# Altium schematic sheet AUDIO&TF.SchDoc(AUDIO&TF)
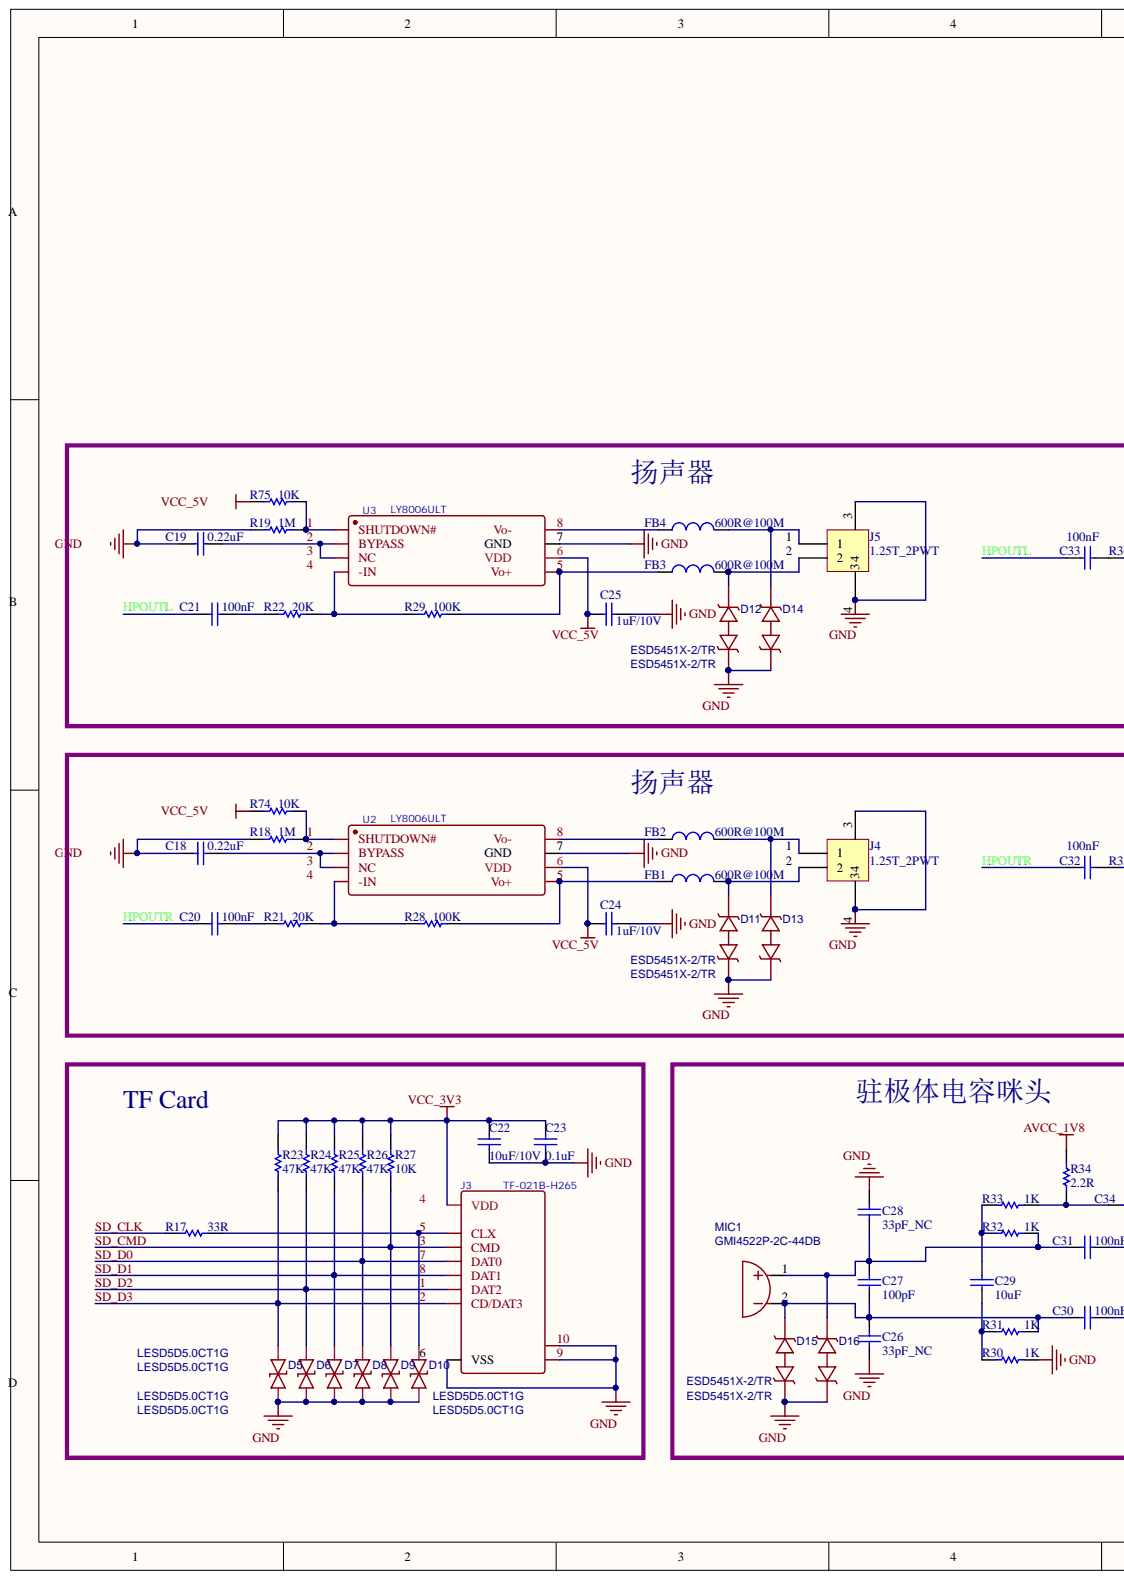
[diagram p0: AUDIO&TF.SchDoc, left]
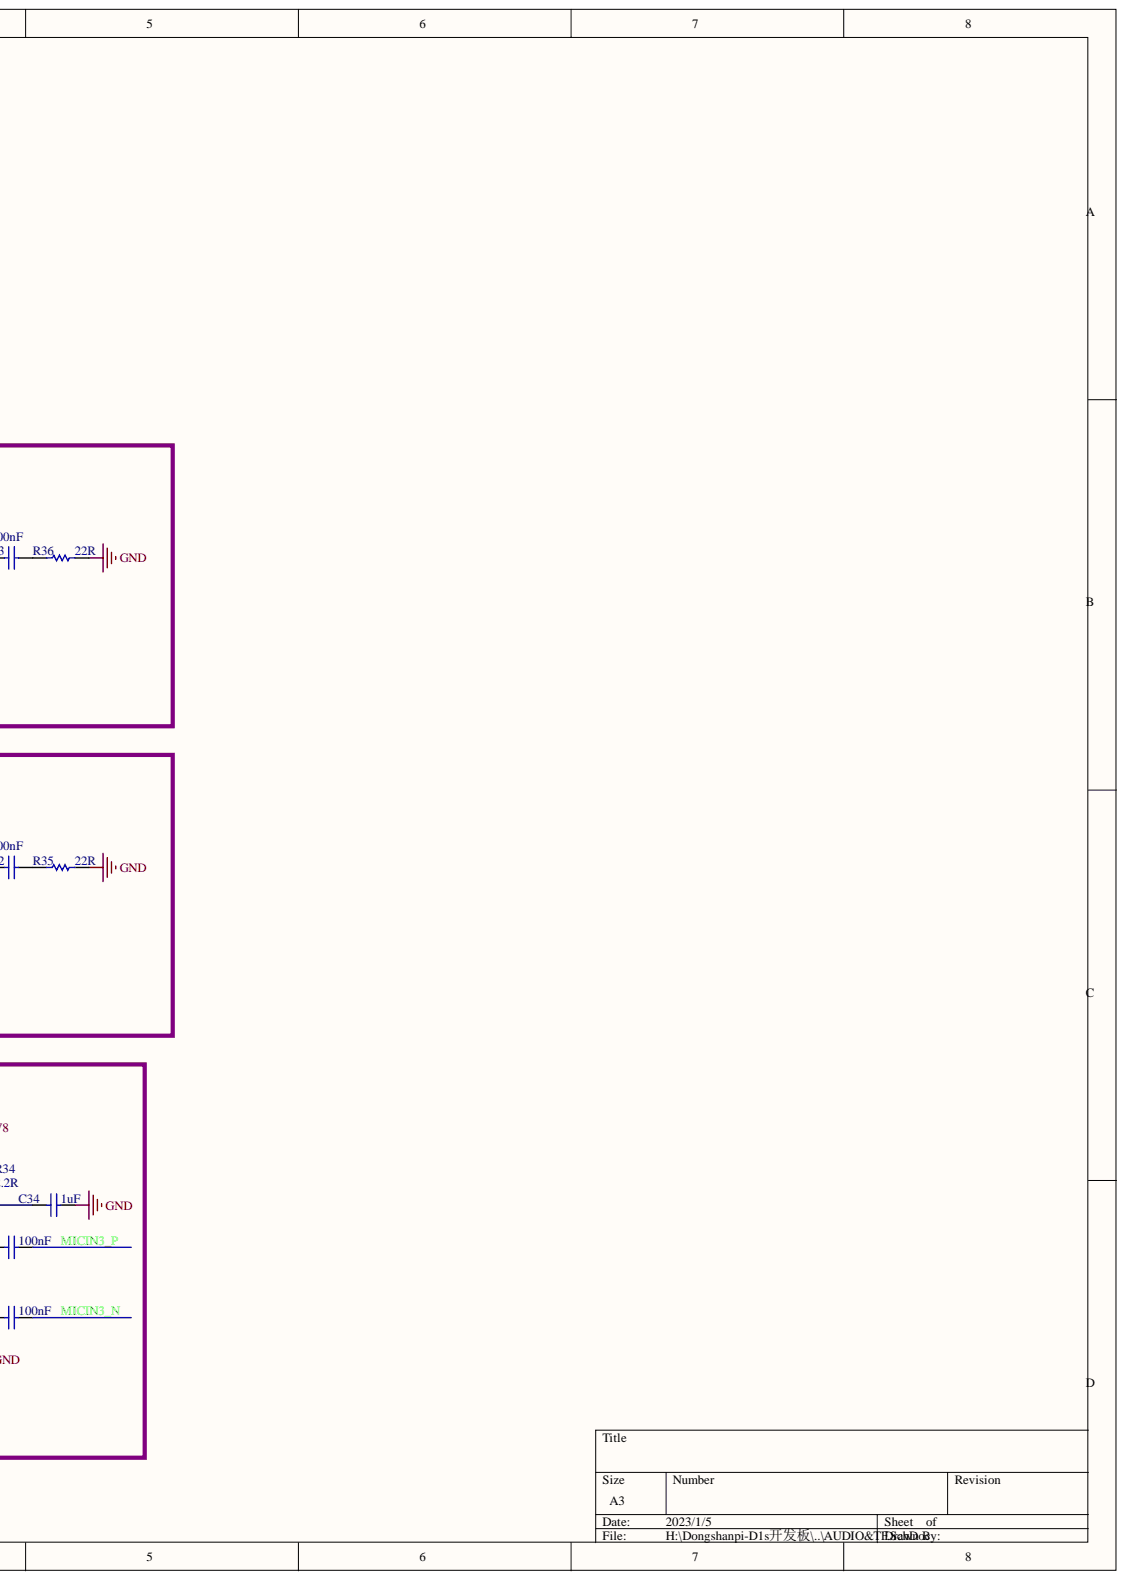
[diagram p1: AUDIO&TF.SchDoc, right]

## Parts
C18  0.22uF  [0402]
C19  0.22uF  [0402]
C20  100nF  [0402]
C21  100nF  [0402]
C22  10uF/10V  [0402]
C23  0.1uF  [0402]  (value not drawn)
C24  1uF/10V  [0402]
C25  1uF/10V  [0402]
C26  33pF_NC  [0402]
C27  100pF  [0402]
C28  33pF_NC  [0402]
C29  10uF  [0603]
C30  100nF  [0402]
C31  100nF  [0402]
C32  100nF  [0402]
C33  100nF  [0402]
C34  1uF  [0402]
D5  LESD5D5.0CT1G  [SOD-523_L1.2-W0.8-LS1.6-BI]
D6  LESD5D5.0CT1G  [SOD-523_L1.2-W0.8-LS1.6-BI]
D7  LESD5D5.0CT1G  [SOD-523_L1.2-W0.8-LS1.6-BI]
D8  LESD5D5.0CT1G  [SOD-523_L1.2-W0.8-LS1.6-BI]
D9  LESD5D5.0CT1G  [SOD-523_L1.2-W0.8-LS1.6-BI]
D10  LESD5D5.0CT1G  [SOD-523_L1.2-W0.8-LS1.6-BI]
D11  ESD5451X-2/TR  [FBP-02C_L1.0-W0.6-RD]
D12  ESD5451X-2/TR  [FBP-02C_L1.0-W0.6-RD]
D13  ESD5451X-2/TR  [FBP-02C_L1.0-W0.6-RD]
D14  ESD5451X-2/TR  [FBP-02C_L1.0-W0.6-RD]
D15  ESD5451X-2/TR  [FBP-02C_L1.0-W0.6-RD]
D16  ESD5451X-2/TR  [FBP-02C_L1.0-W0.6-RD]
FB1  600R@100M  [0603]
FB2  600R@100M  [0603]
FB3  600R@100M  [0603]
FB4  600R@100M  [0603]
J3  TF-021B-H265  [TF-SMD_TF-021B-H265]
J4  1.25T_2PWT  [CONN-SMD_P1.25-H_1.25T-2PWT]
J5  1.25T_2PWT  [CONN-SMD_P1.25-H_1.25T-2PWT]
MIC1  GMI4522P-2C-44DB  [MIC-TH_BD4.5-P1.60-D0.40-L-FD]
R17  33R  [0402]
R18  1M  [0402]
R19  1M  [0402]
R21  20K  [0402]
R22  20K  [0402]
R23  47K  [0402]
R24  47K  [0402]
R25  47K  [0402]
R26  47K  [0402]
R27  10K  [0402]
R28  100K  [0402]
R29  100K  [0402]
R30  1K  [0402]
R31  1K  [0402]
R32  1K  [0402]
R33  1K  [0402]
R34  2.2R  [0402]
R35  22R  [0402]
R36  22R  [0402]
R74  10K  [0402]
R75  10K  [0402]
U2  LY8006ULT  [MSOP-8_L3.0-W3.0-P0.65-LS5.0-BL]
U3  LY8006ULT  [MSOP-8_L3.0-W3.0-P0.65-LS5.0-BL]

## Nets
AVCC_1V8: R34.1
GND: C18.2 C19.2 C22.2 C23.2 C24.1 C25.1 C26.2 C28.2 C34.1 D5.1 D6.1 D7.1 D8.1 D9.1 D10.1 D11.1 D12.1 D13.1 D14.1 D15.1 D16.1 J3.6 J3.9 J3.10 J4.3 J4.4 J5.3 J5.4 R18.1 R19.1 R30.2 R35.1 R36.1 U2.7 U3.7
VCC_3V3: C22.1 C23.1 J3.4 R23.1 R24.1 R25.1 R26.1 R27.1
VCC_5V: C24.2 C25.2 R74.1 R75.1 U2.6 U3.6
HPOUTL: C21.2 C33.1
HPOUTR: C20.2 C32.1
MICIN3_N: C30.1
MICIN3_P: C31.1
SD_CLK: R17.2
SD_CMD: D9.2 J3.3 R27.2
SD_D0: D8.2 J3.7 R26.2
SD_D1: D7.2 J3.8 R25.2
SD_D2: D6.2 J3.1 R24.2
SD_D3: D5.2 J3.2 R23.2
NetC18_1: C18.1 U2.2 U2.3
NetC19_1: C19.1 U3.2 U3.3
NetC20_1: C20.1 R21.1
NetC21_1: C21.1 R22.1
NetC26_1: C26.1 C27.2 C30.2 D15.2 MIC1.2 R31.2
NetC27_1: C27.1 C28.1 C31.2 D16.2 MIC1.1 R32.2
NetC29_1: C29.1 R32.1 R33.1
NetC29_2: C29.2 R30.1 R31.1
NetC32_2: C32.2 R35.2
NetC33_2: C33.2 R36.2
NetC34_2: C34.2 R33.2 R34.2
NetD10_2: D10.2 J3.5 R17.1
NetD11_2: D11.2 FB1.2 J4.2
NetD12_2: D12.2 FB3.2 J5.2
NetD13_2: D13.2 FB2.2 J4.1
NetD14_2: D14.2 FB4.2 J5.1
NetFB1_1: FB1.1 R28.2 U2.5
NetFB2_1: FB2.1 U2.8
NetFB3_1: FB3.1 R29.2 U3.5
NetFB4_1: FB4.1 U3.8
NetR18_2: R18.2 R74.2 U2.1
NetR19_2: R19.2 R75.2 U3.1
NetR21_2: R21.2 R28.1 U2.4
NetR22_2: R22.2 R29.1 U3.4

## Library data of the parts
C18-C34 = Cap: Capacitor; PartNumber: CAP100NF; Component Kind: Standard; LatestRevisionDate: 17-Jul-2002; LatestRevisionNote: Re-released for DXP Platform.; Library Name: Miscellaneous Devices.IntLib; PackageReference: 0402; Published: 8-Jun-2000; Signal Integrity: Cap; Simulation: CAP; Publisher: Altium Limited
  C18 C19: Value: 0.22uF
  C20 C21 C30-C33: Value: 100nF
  C22: Value: 10uF/10V
  C23: Value: 0.1uF
  C24 C25: Value: 1uF/10V
  C26 C28: Value: 33pF_NC
  C27: Value: 100pF
  C29: Value: 10uF
  C34: Value: 1uF
D5-D10 = LESD5D5.0CT1G: BOM_Manufacturer: Leshan Radio; BOM_Paste Type: expand; Contributor: LCSC; BOM_Manufacturer Part: LESD5D5.0CT1G; BOM_Supplier: LCSC; BOM_Supplier Part: C383211; Component Kind: Standard; Library Name: HM2.SchLib; spicePre: D; spiceSymbolName: LESD5D5.0CT1G
D11-D16 = ESD5451X-2/TR: BOM_Manufacturer: Will Semicon; Contributor: LCSC; BOM_JLCPCB Part Class: Extended Part; BOM_Manufacturer Part: ESD5451X-2/TR; BOM_Supplier: LCSC; BOM_Supplier Part: C239558; Component Kind: Standard; spicePre: D; spiceSymbolName: ESD5451X-2/TR
FB1-FB4 = Inductor: Component Kind: Standard; Library Name: MyDevice.SchLib; Value: 600R@100M
J4 J5 = 1.25T_2P: Component Kind: Standard; Library Name: HM2.SchLib
MIC1 = GMI4522P-2C-44DB: BOM_Manufacturer: INGHAi; Contributor: LCSC; BOM_JLCPCB Part Class: Extended Part; BOM_Manufacturer Part: GMI4522P-2C-44db; BOM_Supplier: LCSC; BOM_Supplier Part: C233312; Component Kind: Standard; spicePre: M; spiceSymbolName: GMI4522P-2C-44DB
R17-R19 R21-R36 R74 R75 = Res1: Resistor; PartNumber: RES2.2K; Component Kind: Standard; LatestRevisionDate: 17-Jul-2002; LatestRevisionNote: Re-released for DXP Platform.; Library Name: Miscellaneous Devices.IntLib; PackageReference: 0402; Published: 8-Jun-2000; Signal Integrity: Res; Simulation: RESISTOR; Publisher: Altium Limited
  R17: Value: 33R
  R18 R19: Value: 1M
  R21 R22: Value: 20K
  R23-R26: Value: 47K
  R27 R74 R75: Value: 10K
  R28 R29: Value: 100K
  R30-R33: Value: 1K
  R34: Value: 2.2R
  R35 R36: Value: 22R
J3 = TF-021B-H265: Component Kind: Standard; JLC NO: C498185; Library Name: HM2.SchLib
U2 U3 = LY8006ULT: Component Kind: Standard; JLC NO: C261883
